# Altium schematic sheet Sheet5.SchDoc
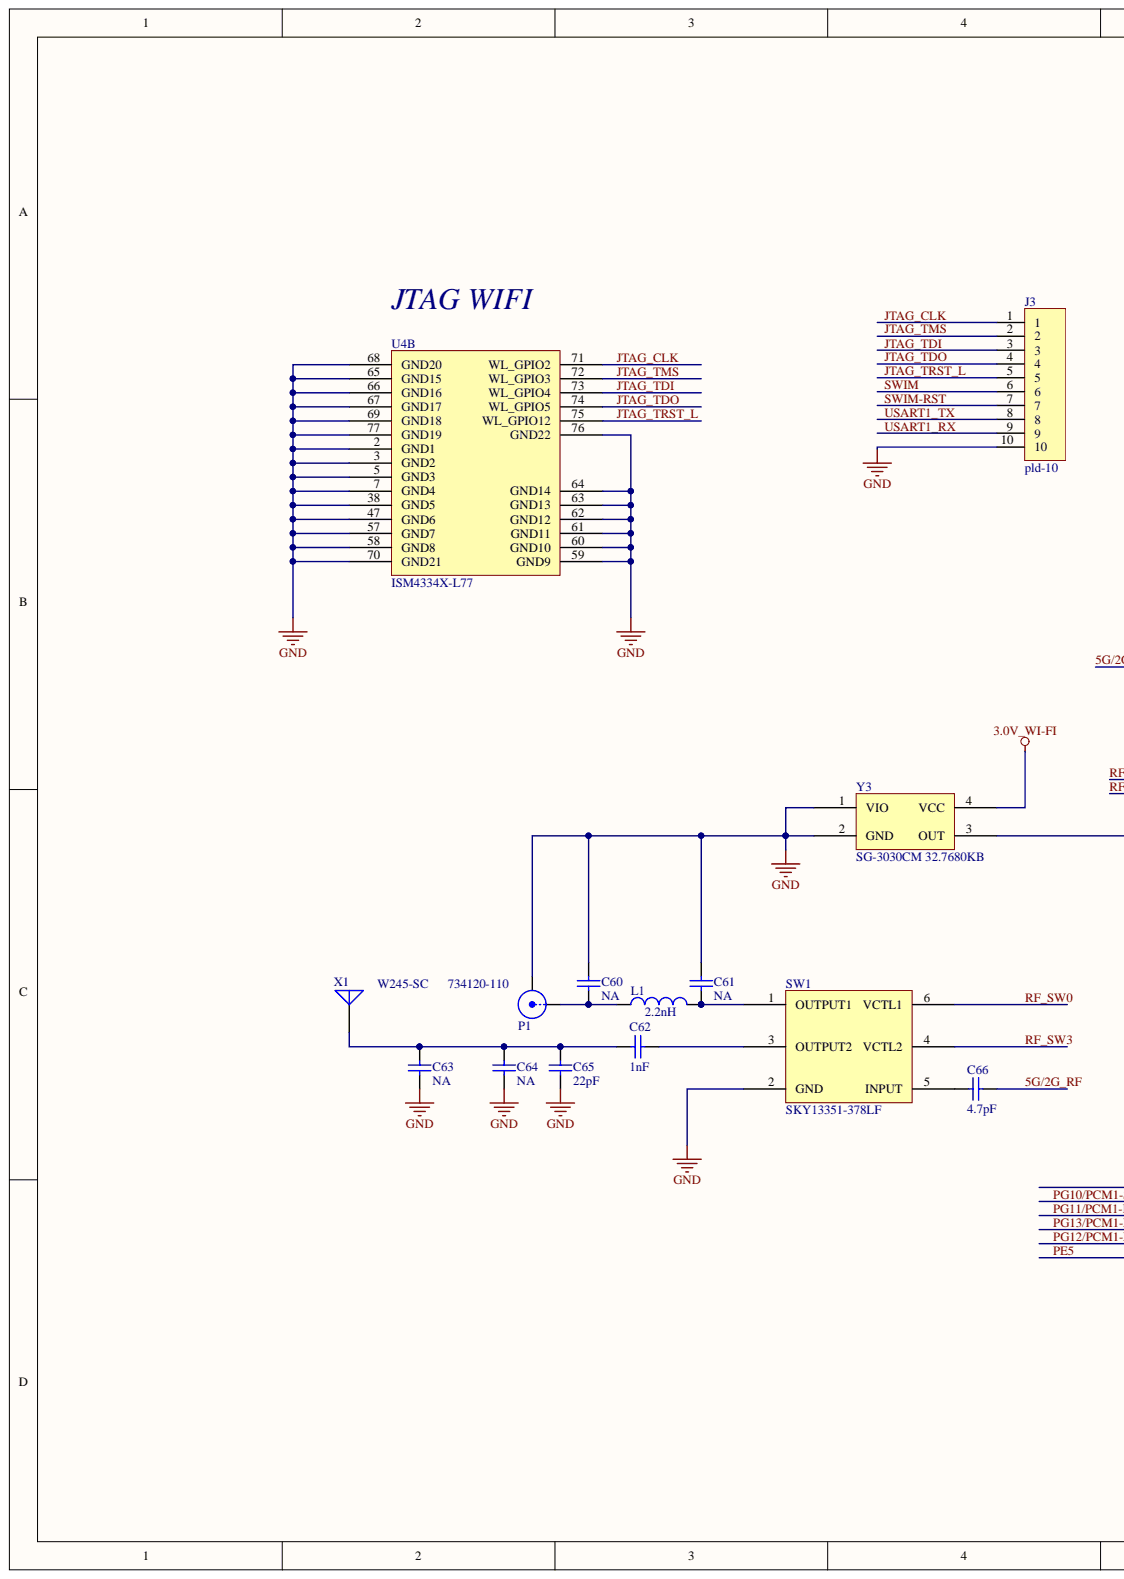
[diagram p0: Sheet5.SchDoc, left]
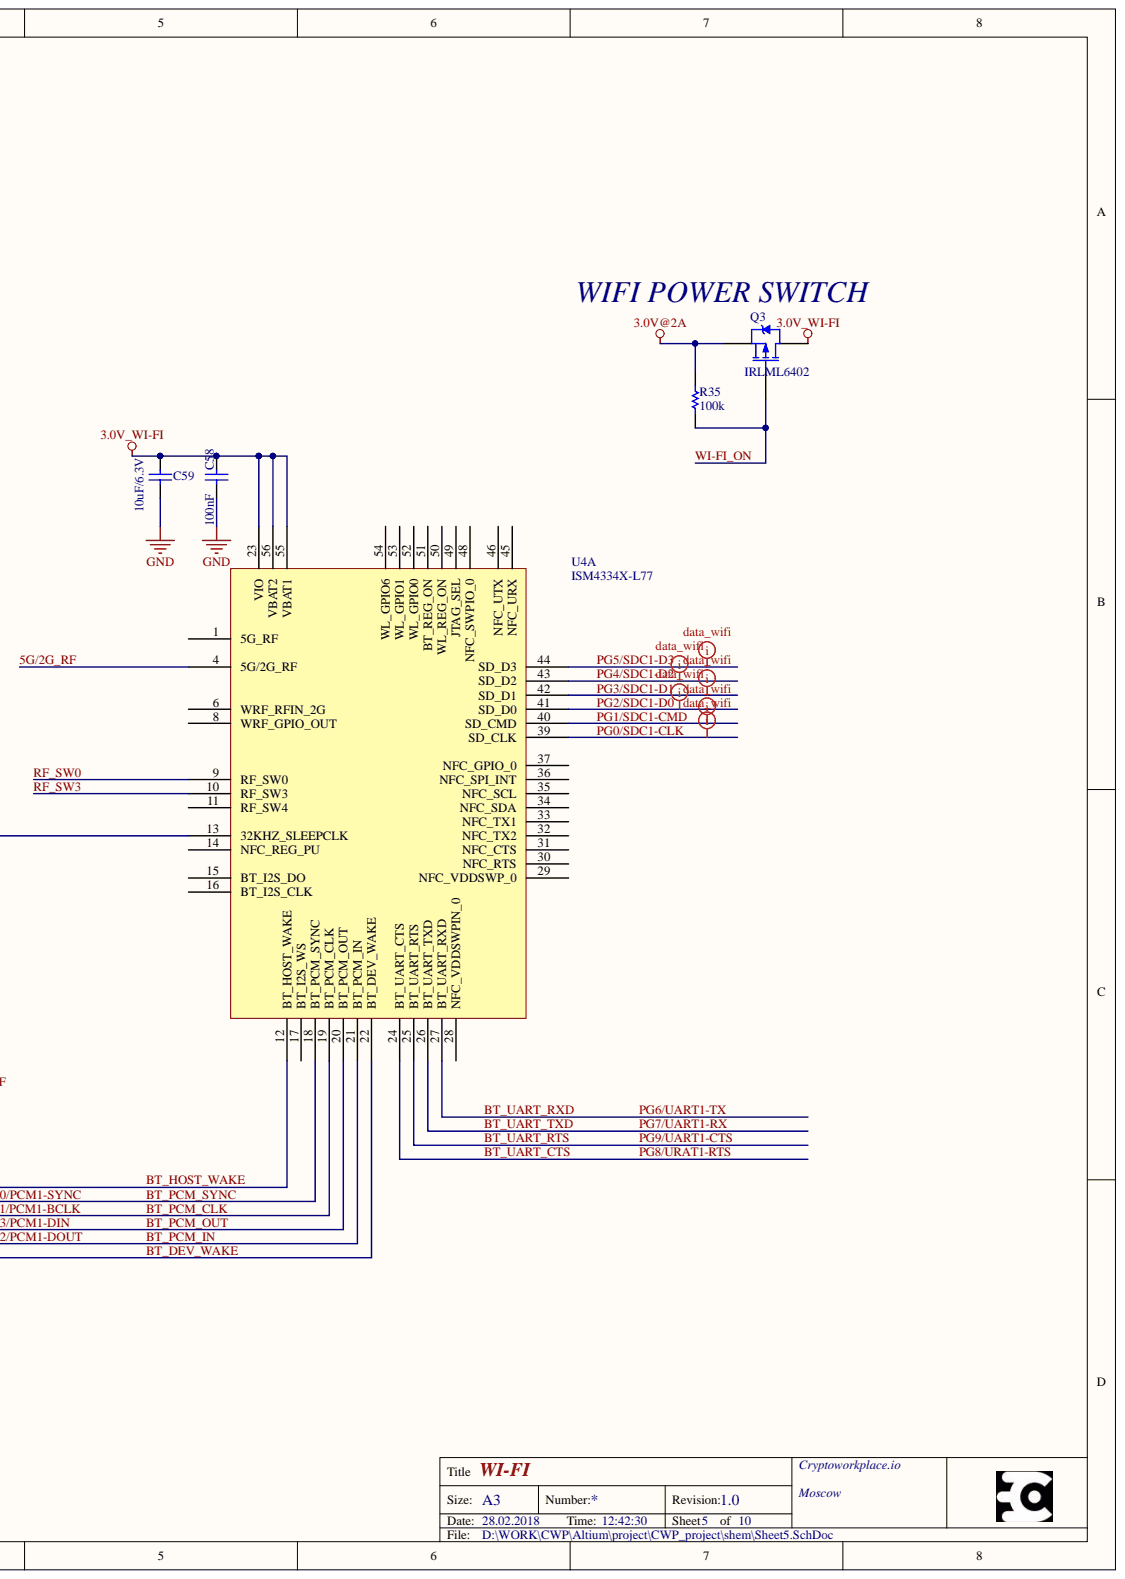
[diagram p1: Sheet5.SchDoc, right]

## Parts
C58  value 100nF  [0603(CAPC1608X09N)]  (hidden comment: Cap)
C59  value 10uF/6.3V  [0603(CAPC1608X09N)]  (hidden comment: Cap)
C60  value NA  [0402(CAPC1005X06N)]  (hidden comment: 0402)
C61  value NA  [0402(CAPC1005X06N)]  (hidden comment: 0402)
C62  value 1nF  [0402(CAPC1005X06N)]  (hidden comment: 0402)
C63  value NA  [0402(CAPC1005X06N)]  (hidden comment: 0402)
C64  value NA  [0402(CAPC1005X06N)]  (hidden comment: 0402)
C65  value 22pF  [0402(CAPC1005X06N)]  (hidden comment: 0402)
C66  value 4.7pF  [0402(CAPC1005X06N)]  (hidden comment: 0402)
J3  pld-10  [pld_10_toconnect]
L1  value 2.2nH  [0402(IND1005X06N)]
P1  734120-110  [73412-0110]
Q3  IRLML6402  [IRLML6402]
R35  value 100k  [0402(RESC1005X04N)]  (hidden comment: Res1)
SW1  SKY13351-378LF  [SKY13351-378LF]
U4  ISM4334X-L77  [IMS4334x]  (parts A,B)
X1  W245-SC  [W245-SC]
Y3  SG-3030CM 32.7680KB  [SG-3030CM 32.7680KB]

## Nets
3.0V@2A: Q3.3 R35.1
3.0V_WI-FI: C58.2 C59.2 Q3.2 U4.23(VIO) U4.55(VBAT1) U4.56(VBAT2) Y3.4(VCC)
GND: C58.1 C59.1 C60.1 C61.1 C63.2 C64.2 C65.2 J3.10 P1.2 SW1.2(GND) U4.2(GND1) U4.3(GND2) U4.5(GND3) U4.7(GND4) U4.38(GND5) U4.47(GND6) U4.57(GND7) U4.58(GND8) U4.59(GND9) U4.60(GND10) U4.61(GND11) U4.62(GND12) U4.63(GND13) U4.64(GND14) U4.65(GND15) U4.66(GND16) U4.67(GND17) U4.68(GND20) U4.69(GND18) U4.70(GND21) U4.76(GND22) U4.77(GND19) Y3.1(VIO) Y3.2(GND)
5G/2G_RF: C66.1 U4.4(5G/2G_RF)
BT_DEV_WAKE: U4.22(BT_DEV_WAKE)
BT_HOST_WAKE: U4.12(BT_HOST_WAKE)
BT_PCM_CLK: U4.19(BT_PCM_CLK)
BT_PCM_IN: U4.21(BT_PCM_IN)
BT_PCM_OUT: U4.20(BT_PCM_OUT)
BT_PCM_SYNC: U4.18(BT_PCM_SYNC)
BT_UART_CTS: U4.24(BT_UART_CTS)
BT_UART_RTS: U4.25(BT_UART_RTS)
BT_UART_RXD: U4.27(BT_UART_RXD)
BT_UART_TXD: U4.26(BT_UART_TXD)
JTAG_CLK: J3.1 U4.71(WL_GPIO2)
JTAG_TDI: J3.3 U4.73(WL_GPIO4)
JTAG_TDO: J3.4 U4.74(WL_GPIO5)
JTAG_TMS: J3.2 U4.72(WL_GPIO3)
JTAG_TRST_L: J3.5 U4.75(WL_GPIO12)
PG0/SDC1-CLK: U4.39(SD_CLK)
PG1/SDC1-CMD: U4.40(SD_CMD)
PG2/SDC1-D0: U4.41(SD_D0)
PG3/SDC1-D1: U4.42(SD_D1)
PG4/SDC1-D2: U4.43(SD_D2)
PG5/SDC1-D3: U4.44(SD_D3)
RF_SW0: SW1.6(VCTL1) U4.9(RF_SW0)
RF_SW3: SW1.4(VCTL2) U4.10(RF_SW3)
SWIM: J3.6
SWIM-RST: J3.7
USART1_RX: J3.9
USART1_TX: J3.8
WI-FI_ON: Q3.1 R35.2
NetC60_2: C60.2 L1.1 P1.1
NetC61_2: C61.2 L1.2 SW1.1(OUTPUT1)
NetC62_1: C62.1 SW1.3(OUTPUT2)
NetC62_2: C62.2 C63.1 C64.1 C65.1 X1.1
NetC66_2: C66.2 SW1.5(INPUT)
NetU4_13: U4.13(32KHZ_SLEEPCLK) Y3.3(OUT)

Not connected: U4 1(5G_RF) 6(WRF_RFIN_2G) 8(WRF_GPIO_OUT) 11(RF_SW4) 14(NFC_REG_PU) 15(BT_I2S_DO) 16(BT_I2S_CLK) 17(BT_I2S_WS) 28(NFC_VDDSWPIN_0) 29(NFC_VDDSWP_0) 30(NFC_RTS) 31(NFC_CTS) 32(NFC_TX2) 33(NFC_TX1) 34(NFC_SDA) 35(NFC_SCL) 36(NFC_SPI_INT) 37(NFC_GPIO_0) 45(NFC_URX) 46(NFC_UTX) 48(NFC_SWPIO_0) 49(JTAG_SEL) 50(WL_REG_ON) 51(BT_REG_ON) 52(WL_GPIO0) 53(WL_GPIO1) 54(WL_GPIO6)

## Library data of the parts
C58-C66 = Cap: Capacitor; Component Kind: Standard; LatestRevisionDate: 17-Jul-2002; LatestRevisionNote: Re-released for DXP Platform.; Library Name: Miscellaneous Devices.IntLib; PackageDescription: Radial Cap, Thru-Hole; 2 Leads; 0.3 in Pin Spacing; PackageReference: RAD-0.3; Published: 8-Jun-2000; Signal Integrity: Cap; Simulation: CAP; Publisher: Altium Limited
J3 = BH2-10: Component Kind: Standard; Description: BH2-10; Library Name: sockets.SchLib
L1 = Inductor: Code_IEC: 1005; Code_JEITA: 0402; Component Kind: Standard; Description: Inductor; LatestRevisionDate: 29-May-2009; LatestRevisionNote: IPC-7351 Footprint Added.; Library Name: Miscellaneous Devices.IntLib; PackageDescription: Chip Inductor; PackageReference: 0402-A; Published: 8-Jun-2000; Signal Integrity: Inductor; Simulation: INDUCTOR; Publisher: Altium Limited
P1 = SMB: RF/Coax Connectors; Component Kind: Standard; LatestRevisionDate: 17-Jul-2002; LatestRevisionNote: Re-released for DXP Platform.; Library Name: Miscellaneous Connectors.IntLib; Signal Integrity: Connector; Publisher: Altium Limited
Q3 = PMOS-2: P-Channel Power MOSFET; Note: Pin 4 not drawn and electrically connected to Pin 2.; Component Kind: Standard; ComponentLink1Description: Manufacturer Link; ComponentLink1URL: http://www.irf.com/; DatasheetVersion: PD-9.390D; LatestRevisionDate: 17-Jul-2002; LatestRevisionNote: Re-released for DXP Platform.; Library Name: Miscellaneous Devices.IntLib; PackageDescription: TO, Thru-Hole, Vertical, Heatsink Mounted; 3 In-Line Leads; Pitch 2.54 mm; PackageReference: TO-220AB; PackageVersion: Feb-1997; Published: 8-Jun-2000; Simulation: IRF9510; Publisher: Altium Limited
R35 = Res1: Resistor; Component Kind: Standard; LatestRevisionDate: 17-Jul-2002; LatestRevisionNote: Re-released for DXP Platform.; Library Name: Miscellaneous Devices.IntLib; PackageDescription: Axial Device, Thru-Hole; 2 Leads; 0.3 in Pin Spacing; PackageReference: AXIAL-0.3; Published: 8-Jun-2000; Signal Integrity: Res; Simulation: RESISTOR; Publisher: Altium Limited
U4 = ISM4334X-L77: ISM4334X-L77 Embedded SiP Module  802.11 a/b/g/n  Bluetooth 4.0 &  NFC Data Sheet; Component Kind: Standard; Library Name: INVENTEK SYSTEMS.SchLib
X1 = Antenna: Generic Antenna; Component Kind: Standard; LatestRevisionDate: 17-Jul-2002; LatestRevisionNote: Re-released for DXP Platform.; Library Name: Miscellaneous Devices.IntLib; PackageReference: PIN1; Published: 24-Mar-1999; Publisher: Altium Limited
SW1 = SKY13351-378LF: Component Kind: Standard; Description: SKY13351-378LF; Library Name: INVENTEK SYSTEMS.SchLib
Y3 = SG-3030CM 32.7680KB: Component Kind: Standard; Description: SG-3030CM 32.7680KB; Library Name: INVENTEK SYSTEMS.SchLib
